# Altium schematic sheet 02_Simple_ARM.SchDoc(02_Simple_ARM)
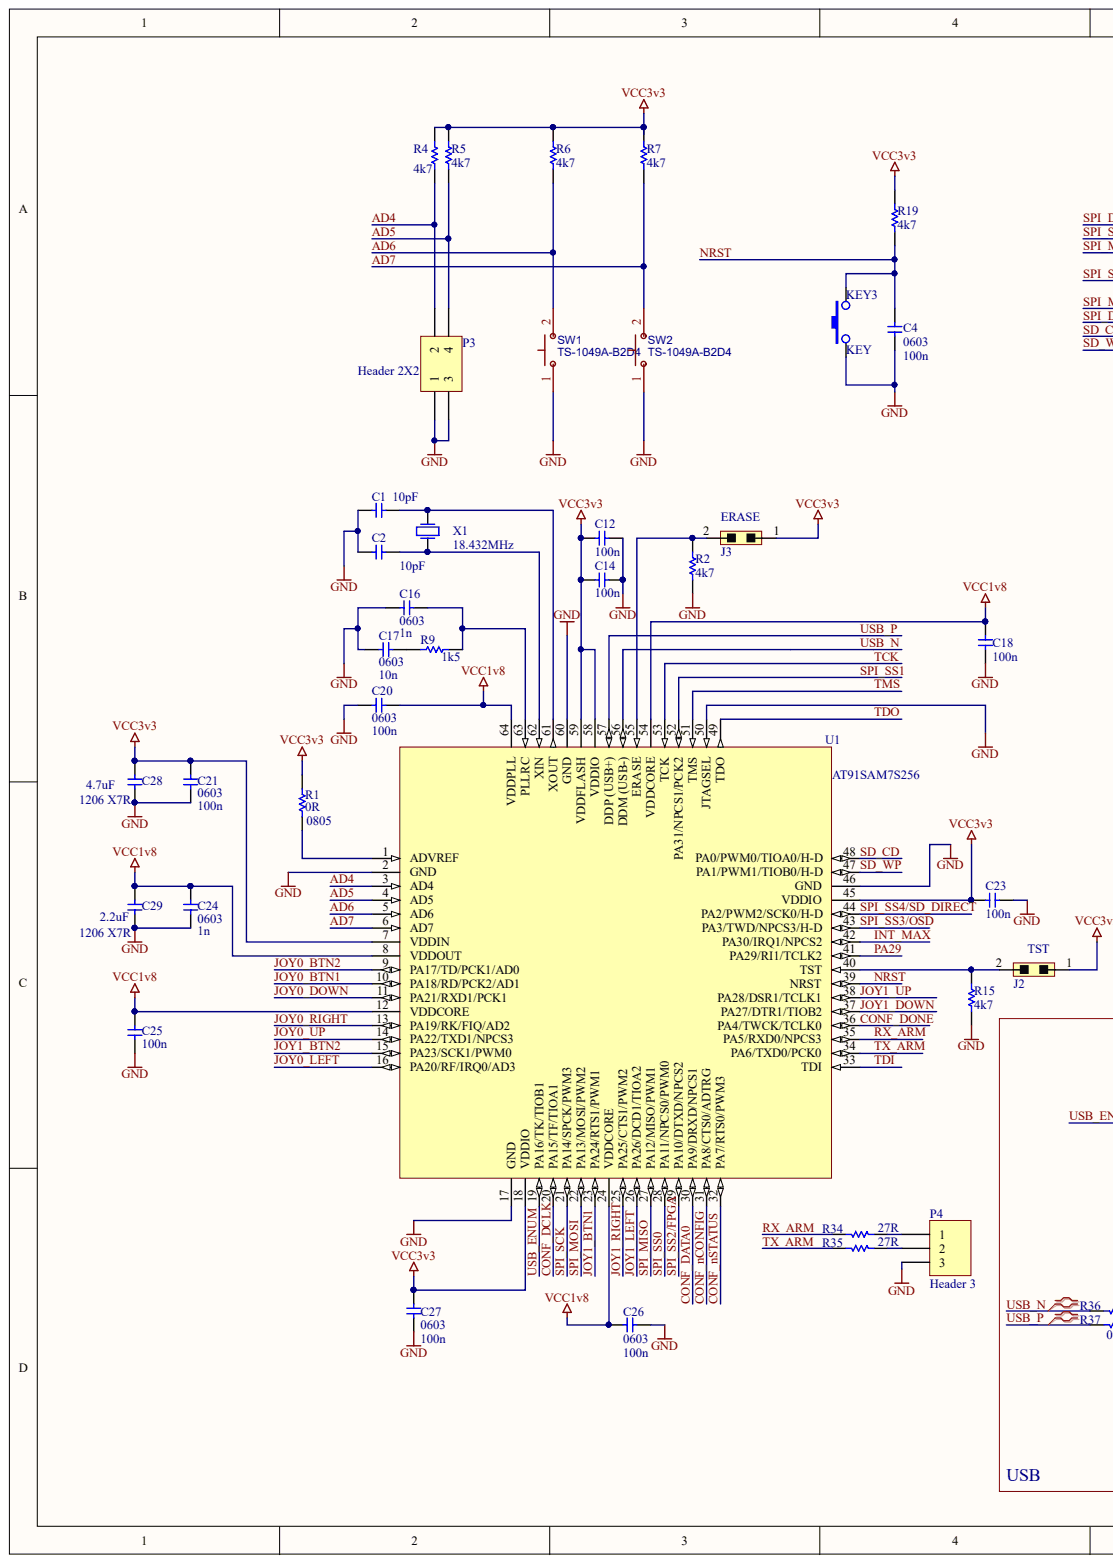
[diagram p0: 02_Simple_ARM.SchDoc, left]
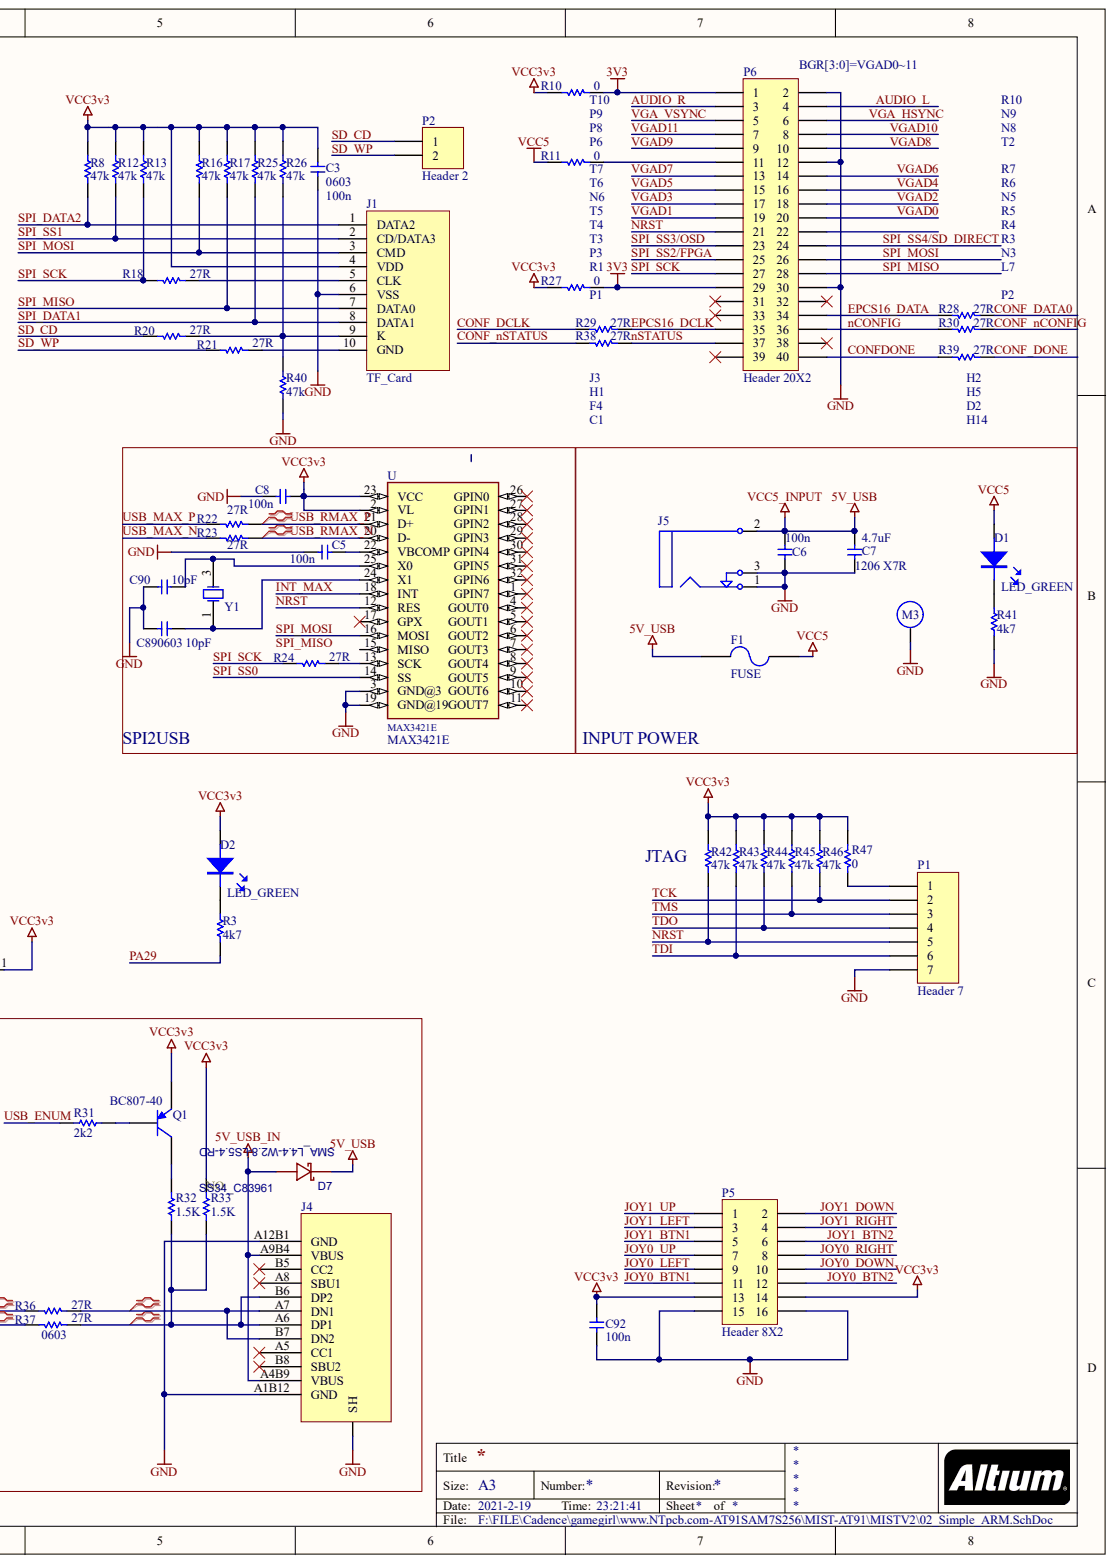
[diagram p1: 02_Simple_ARM.SchDoc, right]

## Parts
C1  value 10pF  [0603]  (hidden comment: 0603)
C2  value 10pF  [0603]  (hidden comment: 0603)
C3  0603  value 100n  [0603]
C4  0603  value 100n  [0603]
C5  value 100n  [0603]  (hidden comment: 0603)
C6  value 100n  [0603]  (hidden comment: 0603)
C7  1206 X7R  value 4.7uF  [1206]
C8  value 100n  [0603]  (hidden comment: 0603)
C12  value 100n  [0603]  (hidden comment: 0603)
C14  value 100n  [0603]  (hidden comment: 0603)
C16  0603  value 1n  [0603]
C17  0603  value 10n  [0603]
C18  value 100n  [0603]  (hidden comment: 0603)
C20  0603  value 100n  [0603]
C21  0603  value 100n  [0603]
C23  value 100n  [0603]  (hidden comment: 0603)
C24  0603  value 1n  [0603]
C25  value 100n  [0603]  (hidden comment: 0603)
C26  0603  value 100n  [0603]
C27  0603  value 100n  [0603]
C28  1206 X7R  value 4.7uF  [1206]
C29  1206 X7R  value 2.2uF  [1206]
C89  0603  value 10pF  [0603]
C90  value 10pF  [0603]  (hidden comment: 0603)
C92  value 100n  [0603]  (hidden comment: 0603)
D1  LED_GREEN  [LED-0603G]
D2  LED_GREEN  [LED-0603G]
D7  SS34_C83961  [SMA_L4.4-W2.8-LS5.4-RD]
F1  FUSE  [FUSE_1206]
H1  (no value)  [M3]
J1  TF_Card  [TF1]
J2  JUMPER2  [JUMPER2_254]  (value not drawn)
J3  JUMPER2  [JUMPER2_254]  (value not drawn)
J4  Type_C_16P  [Type_C_16P]  (value not drawn)
J5  (no value)  [PWR-2mm - DNI]
KEY3  KEY  [SMD-3X6X2.5]
P1  Header 7  [HDR1X7]
P2  Header 2  [HDR1X2]
P3  Header 2X2  [HDR2X2]
P4  Header 3  [HDR1X3]
P5  Header 8X2  [HDR2X8]
P6  Header 20X2  [HDR2X20]
Q1  BC807-40  [SO-G3/Y1]
R1  0805  value 0R  [0603]
R2  value 4k7  [0603]  (hidden comment: 0805)
R3  value 4k7  [0603]  (hidden comment: 0603)
R4  value 4k7  [0603]  (hidden comment: 0805)
R5  value 4k7  [0603]  (hidden comment: 0805)
R6  value 4k7  [0603]  (hidden comment: 0805)
R7  value 4k7  [0603]  (hidden comment: 0805)
R8  value 47k  [0603]  (hidden comment: 0805)
R9  0603  value 1k5  [0603]
R10  value 0  [0603]  (hidden comment: 0603)
R11  value 0  [0603]  (hidden comment: 0603)
R12  value 47k  [0603]  (hidden comment: 0805)
R13  value 47k  [0603]  (hidden comment: 0805)
R15  value 4k7  [0603]  (hidden comment: 0805)
R16  value 47k  [0603]  (hidden comment: 0805)
R17  value 47k  [0603]  (hidden comment: 0805)
R18  value 27R  [0603]  (hidden comment: 0603)
R19  value 4k7  [0603]  (hidden comment: 0805)
R20  value 27R  [0603]  (hidden comment: 0603)
R21  value 27R  [0603]  (hidden comment: 0603)
R22  value 27R  [0603]  (hidden comment: 0603)
R23  value 27R  [0603]  (hidden comment: 0603)
R24  value 27R  [0603]  (hidden comment: 0603)
R25  value 47k  [0603]  (hidden comment: 0805)
R26  value 47k  [0603]  (hidden comment: 0805)
R27  value 0  [0603]  (hidden comment: 0603)
R28  value 27R  [0603]  (hidden comment: 0603)
R29  value 27R  [0603]  (hidden comment: 0603)
R30  value 27R  [0603]  (hidden comment: 0603)
R31  value 2k2  [0603]  (hidden comment: 0805)
R32  value 1.5K  [0603]  (hidden comment: R0603)
R33  value 1.5K  STUFF: NO  [0603]  (hidden comment: R0603)
R34  value 27R  [0603]  (hidden comment: 0603)
R35  value 27R  [0603]  (hidden comment: 0603)
R36  0603  value 27R  [0603]
R37  0603  value 27R  [0603]
R38  value 27R  [0603]  (hidden comment: 0603)
R39  value 27R  [0603]  (hidden comment: 0603)
R40  value 47k  [0603]  (hidden comment: 0805)
R41  value 4k7  [0603]  (hidden comment: 0805)
R42  value 47k  [0603]  (hidden comment: 0805)
R43  value 47k  [0603]  (hidden comment: 0805)
R44  value 47k  [0603]  (hidden comment: 0805)
R45  value 47k  [0603]  (hidden comment: 0805)
R46  value 47k  [0603]  (hidden comment: 0805)
R47  value 0  [0603]  (hidden comment: 0603)
SW1  TS-1049A-B2D4  [SW-SMD_L3.0-W2.0-LS3.5]
SW2  TS-1049A-B2D4  [SW-SMD_L3.0-W2.0-LS3.5]
U  MAX3421E  [TQFP-32_L5.0-W5.0-P0.50-LS7.0-BL]
U1  AT91SAM7S256  [LQFP64-10-05]
X1  18.432MHz  [CRYSTAL_SMT]
Y1  12MHZ 20PF 10PPM  [SMD-3225_4P]  (value not drawn)

## Nets
3V3: P6.1 P6.29 R10.1 R27.1
5V_USB: C6.2 C7.2 D7.1 F1.1 J5.2
5V_USB_IN: D7.2 J4.A4B9(VBUS) J4.A9B4(VBUS)
GND: C1.2 C2.2 C3.1 C4.1 C5.2 C6.1 C7.1 C8.2 C12.2 C14.2 C16.1 C17.1 C18.1 C20.1 C21.1 C23.1 C24.1 C25.1 C26.2 C27.1 C28.1 C29.1 C89.2 C90.2 C92.2 H1.1 J1.6(VSS) J4.0(SH) J4.A1B12(GND) J4.A12B1(GND) J5.1 J5.3 KEY3.1 P1.7 P3.1 P3.3 P4.3 P5.15 P5.16 P6.2 P6.12 P6.30 R2.1 R15.2 R40.1 R41.2 SW1.1 SW2.1 U.3(GND@3) U.19(GND@19) U1.2(GND) U1.17(GND) U1.46(GND) U1.50(JTAGSEL) U1.60(GND)
VCC1V8: C18.2 C20.2 C24.2 C25.2 C26.1 C29.2 U1.8(VDDOUT) U1.12(VDDCORE) U1.24(VDDCORE) U1.54(VDDCORE) U1.64(VDDPLL)
VCC3V3: C3.2 C8.1 C12.1 C14.1 C21.2 C23.2 C27.2 C28.2 C92.1 D2.A J1.4(VDD) J2.1 J3.1 P5.13 P5.14 Q1.2 R1.2 R4.1 R5.1 R6.1 R7.1 R8.2 R10.2 R12.2 R13.2 R16.2 R17.2 R19.1 R25.2 R26.2 R27.2 R33.1 R42.2 R43.2 R44.2 R45.2 R46.2 R47.1 U.2(VL) U.23(VCC) U1.7(VDDIN) U1.18(VDDIO) U1.45(VDDIO) U1.58(VDDIO) U1.59(VDDFLASH)
VCC5: D1.A F1.2 R11.2
AD4: P3.2 R4.2 U1.3(AD4)
AD5: P3.4 R5.2 U1.4(AD5)
AD6: R6.2 SW1.2 U1.5(AD6)
AD7: R7.2 SW2.2 U1.6(AD7)
AUDIO_L: P6.4
AUDIO_R: P6.3
CONF_DATA0: R28.1 U1.30(PA9/DRXD/NPCS1)
CONF_DCLK: R29.2 U1.20(PA15/TF/TIOA1)
CONF_DONE: R39.1 U1.36(PA4/TWCK/TCLK0)
CONF_NCONFIG: R30.1 U1.31(PA8/CTS0/ADTRG)
CONF_NSTATUS: R38.2 U1.32(PA7/RTS0/PWM3)
CONFDONE: P6.40 R39.2
EPCS16_DATA: P6.34 R28.2
EPCS16_DCLK: P6.35 R29.1
INT_MAX: U.18(INT) U1.42(PA30/IRQ1/NPCS2)
JOY0_BTN1: P5.11 U1.10(PA18/RD/PCK2/AD1)
JOY0_BTN2: P5.12 U1.9(PA17/TD/PCK1/AD0)
JOY0_DOWN: P5.10 U1.11(PA21/RXD1/PCK1)
JOY0_LEFT: P5.9 U1.16(PA20/RF/IRQ0/AD3)
JOY0_RIGHT: P5.8 U1.13(PA19/RK/FIQ/AD2)
JOY0_UP: P5.7 U1.14(PA22/TXD1/NPCS3)
JOY1_BTN1: P5.5 U1.23(PA24/RTS1/PWM1)
JOY1_BTN2: P5.6 U1.15(PA23/SCK1/PWM0)
JOY1_DOWN: P5.2 U1.37(PA27/DTR1/TIOB2)
JOY1_LEFT: P5.3 U1.26(PA26/DCD1/TIOA2)
JOY1_RIGHT: P5.4 U1.25(PA25/CTS1/PWM2)
JOY1_UP: P5.1 U1.38(PA28/DSR1/TCLK1)
NCONFIG: P6.36 R30.2
NRST: C4.2 KEY3.3 P1.5 P6.21 R19.2 R42.1 U.12(RES) U1.39(NRST)
NSTATUS: P6.37 R38.1
PA29: R3.2 U1.41(PA29/RI1/TCLK2)
RX_ARM: R34.2 U1.35(PA5/RXD0/NPCS3)
SD_CD: P2.1 R20.2 U1.48(PA0/PWM0/TIOA0/H-D)
SD_WP: P2.2 R21.2 U1.47(PA1/PWM1/TIOB0/H-D)
SPI_DATA1: J1.8(DATA1) R25.1
SPI_DATA2: J1.1(DATA2) R8.1
SPI_MISO: J1.7(DATA0) P6.28 R17.1 U1.27(PA12/MISO/PWM1)
SPI_MOSI: J1.3(CMD) P6.26 R16.1 U.16(MOSI) U1.22(PA13/MOSI/PWM2)
SPI_SCK: P6.27 R13.1 R18.2 R24.2 U1.21(PA14/SPCK/PWM3)
SPI_SS0: U.14(SS) U1.28(PA11/NPCS0/PWM0)
SPI_SS1: J1.2(CD/DATA3) R12.1 U1.52(PA31/NPCS1/PCK2)
SPI_SS2/FPGA: P6.25 U1.29(PA10/DTXD/NPCS2)
SPI_SS3/OSD: P6.23 U1.43(PA3/TWD/NPCS3/H-D)
SPI_SS4/SD_DIRECT: P6.24 U1.44(PA2/PWM2/SCK0/H-D)
TCK: P1.2 R46.1 U1.53(TCK)
TDI: P1.6 R43.1 U1.33(TDI)
TDO: P1.4 R44.1 U1.49(TDO)
TMS: P1.3 R45.1 U1.51(TMS)
TX_ARM: R35.2 U1.34(PA6/TXD0/PCK0)
USB_ENUM: R31.1 U1.19(PA16/TK/TIOB1)
USB_MAX_N: R23.2
USB_MAX_P: R22.2
USB_N: R36.2 U1.56(DDM_(USB-))
USB_P: R37.2 U1.57(DDP_(USB+))
USB_RMAX_N: R23.1 U.20(D-)
USB_RMAX_P: R22.1 U.21(D+)
VGA_HSYNC: P6.6
VGA_VSYNC: P6.5
VGAD0: P6.20
VGAD1: P6.19
VGAD2: P6.18
VGAD3: P6.17
VGAD4: P6.16
VGAD5: P6.15
VGAD6: P6.14
VGAD7: P6.13
VGAD8: P6.10
VGAD9: P6.9
VGAD10: P6.8
VGAD11: P6.7
NetC1_1: C1.1 U1.61(XOUT) X1.2
NetC2_1: C2.1 U1.62(XIN) X1.1
NetC5_1: C5.1 U.22(VBCOMP)
NetC16_2: C16.2 R9.2 U1.63(PLLRC)
NetC17_2: C17.2 R9.1
NetC89_1: C89.1 U.24(X1) Y1.1
NetC90_1: C90.1 U.25(X0) Y1.3
NetD1_K: D1.K R41.1
NetD2_K: D2.K R3.1
NetJ1_5: J1.5(CLK) R18.1
NetJ1_9: J1.9(K) R20.1 R26.1 R40.2
NetJ1_10: J1.10(GND) R21.1
NetJ2_2: J2.2 R15.1 U1.40(TST)
NetJ3_2: J3.2 R2.2 U1.55(ERASE)
NetJ4_A6: J4.A6(DP1) J4.B6(DP2) R32.2 R33.2 R37.1
NetJ4_A7: J4.A7(DN1) J4.B7(DN2) R36.1
NetP1_1: P1.1 R47.2
NetP4_1: P4.1 R34.1
NetP4_2: P4.2 R35.1
NetP6_11: P6.11 R11.1
NetQ1_1: Q1.1 R31.2
NetQ1_3: Q1.3 R32.1
NetR1_1: R1.1 U1.1(ADVREF)
NetR24_1: R24.1 U.13(SCK)
N00032:

Not connected: J4 A5(CC1) A8(SBU1) B5(CC2) B8(SBU2); P6 22 31 32 33 38 39; U 1(GPIN7) 4(GOUT0) 5(GOUT1) 6(GOUT2) 7(GOUT3) 8(GOUT4) 9(GOUT5) 10(GOUT6) 11(GOUT7) 15(MISO) 17(GPX) 26(GPIN0) 27(GPIN1) 28(GPIN2) 29(GPIN3) 30(GPIN4) 31(GPIN5) 32(GPIN6)

## Library data of the parts
C1 C2 C89 C90 = CAP_0805: 0805 footprint non-polarised capacitor; Component Kind: Standard; Library Name: Integrated Comp_lib.IntLib
C3-C6 C8 C12 C14 C18 C21 C23-C27 C92 = CAP_0603: 0603 footprint non-polorised capacitor; Component Kind: Standard; Library Name: Integrated Comp_lib.IntLib
C7 C28 C29 = CAP_1206: 1206 footprint non-polorised capacitor; Component Kind: Standard; Library Name: Integrated Comp_lib.IntLib
C16 = CAP_0603: 0603 footprint non-polorised capacitor; Value: 1n; Component Kind: Standard; Library Name: Integrated Comp_lib.IntLib
C17 = CAP_0603: 0603 footprint non-polorised capacitor; Value: 10n; Component Kind: Standard; Library Name: Integrated Comp_lib.IntLib
C20 = CAP_0603: 0603 footprint non-polorised capacitor; Value: 100n; Component Kind: Standard; Library Name: Integrated Comp_lib.IntLib
D1 D2 = LED: Component Kind: Standard; Library Name: LED.IntLib
D7 = SS34_C83961: BOM_Manufacturer: STARSEA; BOM_Manufacturer Part: SS34; Package Display: Yes; SMT Type: Extend; BOM_JLCPCB SMD: Yes; BOM_Supplier: LCSC; BOM_Supplier Part: C83961; Component Kind: Standard; image: //image.lceda.cn/szlcsc/C83961.jpg; spicePre: D; spiceSymbolName: SS34_C83961
H1 = M3: Component Kind: Standard; Library Name: lib.SchLib
J1 = TF_Card: TF卡座; 版权归属: 源创客; BOM名称: TF卡座; Component Kind: Standard; Library Name: 4.1   - 接口类.SchLib; 学习资源: www.ooocke.com
J2 J3 = JUMPER2: 2 Pin Jumper, 2.54mm; Component Kind: Standard; Library Name: ARM_projects.IntLib
J5 = Powerjack: Component Kind: Standard; Library Name: lib.SchLib
P1 = Header 7: Header, 7-Pin; Component Kind: Standard; LatestRevisionDate: 17-Jul-2002; LatestRevisionNote: Re-released for DXP Platform.; Library Name: Miscellaneous Connectors.IntLib; Signal Integrity: Connector; Publisher: Altium Limited
P2 = Header 2: Header, 2-Pin; Component Kind: Standard; LatestRevisionDate: 17-Jul-2002; LatestRevisionNote: Re-released for DXP Platform.; Library Name: Miscellaneous Connectors.IntLib; Signal Integrity: Connector; Publisher: Altium Limited
P3 = Header 2X2: Header, 2-Pin, Dual row; Component Kind: Standard; LatestRevisionDate: 17-Jul-2002; LatestRevisionNote: Re-released for DXP Platform.; Library Name: Miscellaneous Connectors.IntLib; Signal Integrity: Connector; Publisher: Altium Limited
P4 = Header 3: Header, 3-Pin; Component Kind: Standard; LatestRevisionDate: 17-Jul-2002; LatestRevisionNote: Re-released for DXP Platform.; Library Name: Miscellaneous Connectors.IntLib; Signal Integrity: Connector; Publisher: Altium Limited
P5 = Header 8X2: Header, 8-Pin, Dual row; Component Kind: Standard; LatestRevisionDate: 17-Jul-2002; LatestRevisionNote: Re-released for DXP Platform.; Library Name: Miscellaneous Connectors.IntLib; Signal Integrity: Connector; Publisher: Altium Limited
P6 = Header 20X2: Header, 20-Pin, Dual row; Component Kind: Standard; LatestRevisionDate: 17-Jul-2002; LatestRevisionNote: Re-released for DXP Platform.; Library Name: Miscellaneous Connectors.IntLib; Signal Integrity: Connector; Publisher: Altium Limited
Q1 = BC807-40: PNP General Purpose Amplifier; Manufacturer: Fairchild Semiconductor; Class I: Discrete; Class II: BJT - Low Power; Package Information: Latest Revision: Sep-1998; Revision 1: 11-July-2000: SIM Model Added.; Revision 2: July-2002: Re-released for DXP Platform.; Component Kind: Standard; Datasheet: Latest Revision: 1997; Library Name: FSC Discrete BJT.IntLib; Package Reference: SOT-23A; Published: 8-Jun-2000; Signal Integrity: BC807-40; Simulation: BC807-40; Publisher: Altium Limited
R1-R9 R12 R13 R15-R17 R19 R25 R26 R31 R40-R46 = RES_0805: 0805 footprint resistor; Component Kind: Standard; Library Name: Integrated Comp_lib.IntLib
R10 R11 R18 R20-R24 R27-R30 R34-R39 R47 = RES_0603: 0603 footprint resistor; Component Kind: Standard; Library Name: Integrated Comp_lib.IntLib
R32 R33 = R0603: Resistor; Component Kind: Standard; LatestRevisionDate: 17-Jul-2002; LatestRevisionNote: Re-released for DXP Platform.; Library Name: MYLIB.IntLib; PackageDescription: Axial Device, Thru-Hole; 2 Leads; 0.3 in Pin Spacing; PackageReference: AXIAL-0.3; Published: 8-Jun-2000; Signal Integrity: Res; Simulation: RESISTOR; Publisher: Altium Limited
SW1 SW2 = TS-1049A-B2D4: BOM_Manufacturer: Yuandi; Contributor: LCSC; BOM_Manufacturer Part: TS-1049A-B2D4; BOM_Supplier: LCSC; BOM_Supplier Part: C585167; Component Kind: Standard; spicePre: S; spiceSymbolName: TS-1049A-B2D4
U = MAX3421E: USB Controller USB 2.0 SPI Interface 32-TQFN-EP _5x5_; MF: Maxim Integrated; Availability: Unavailable; Component Kind: Standard; Library Name: MAX3421E.IntLib; MP: MAX3421E; Package: TQFP-32 Maxim Integrated; Price: None; SnapEDA_Link: https://www.snapeda.com/parts/MAX3421E/Maxim%20Integrated/view-part/1077099/?ref=snap
U1 = AT91SAM7S256: Atmel ARM7 microcontroller, 256k Flash; Component Kind: Standard; Library Name: ARM_projects.IntLib; Value: AT91SAM7S256
X1 = CRYSTAL_SMT: SMT crystal; Component Kind: Standard; Library Name: ARM_projects.IntLib; Value: 18.432MHz
Y1 = SMD-3225_4P  12MHZ 20PF 10PPM: 贴片晶振; Component Kind: Standard; ComponentLink1Description: 供应商链接; ComponentLink1URL: http://www.szlcsc.com/product/details_9522.html; Library Name: CrystalOscillator.SchLib; Package: SMD-3225_4P; Supplier: LC; SuppliersPartNumber: C9002
F1 = FUSE: Component Kind: Standard; Library Name: 保险丝.IntLib
J4 = Type_C_16P: Component Kind: Standard; Library Name: ICESugar.SCHLIB
KEY3 = KEY: Component Kind: Standard; Library Name: 轻触开关.IntLib
